# Altium schematic sheet MCU.SchDoc
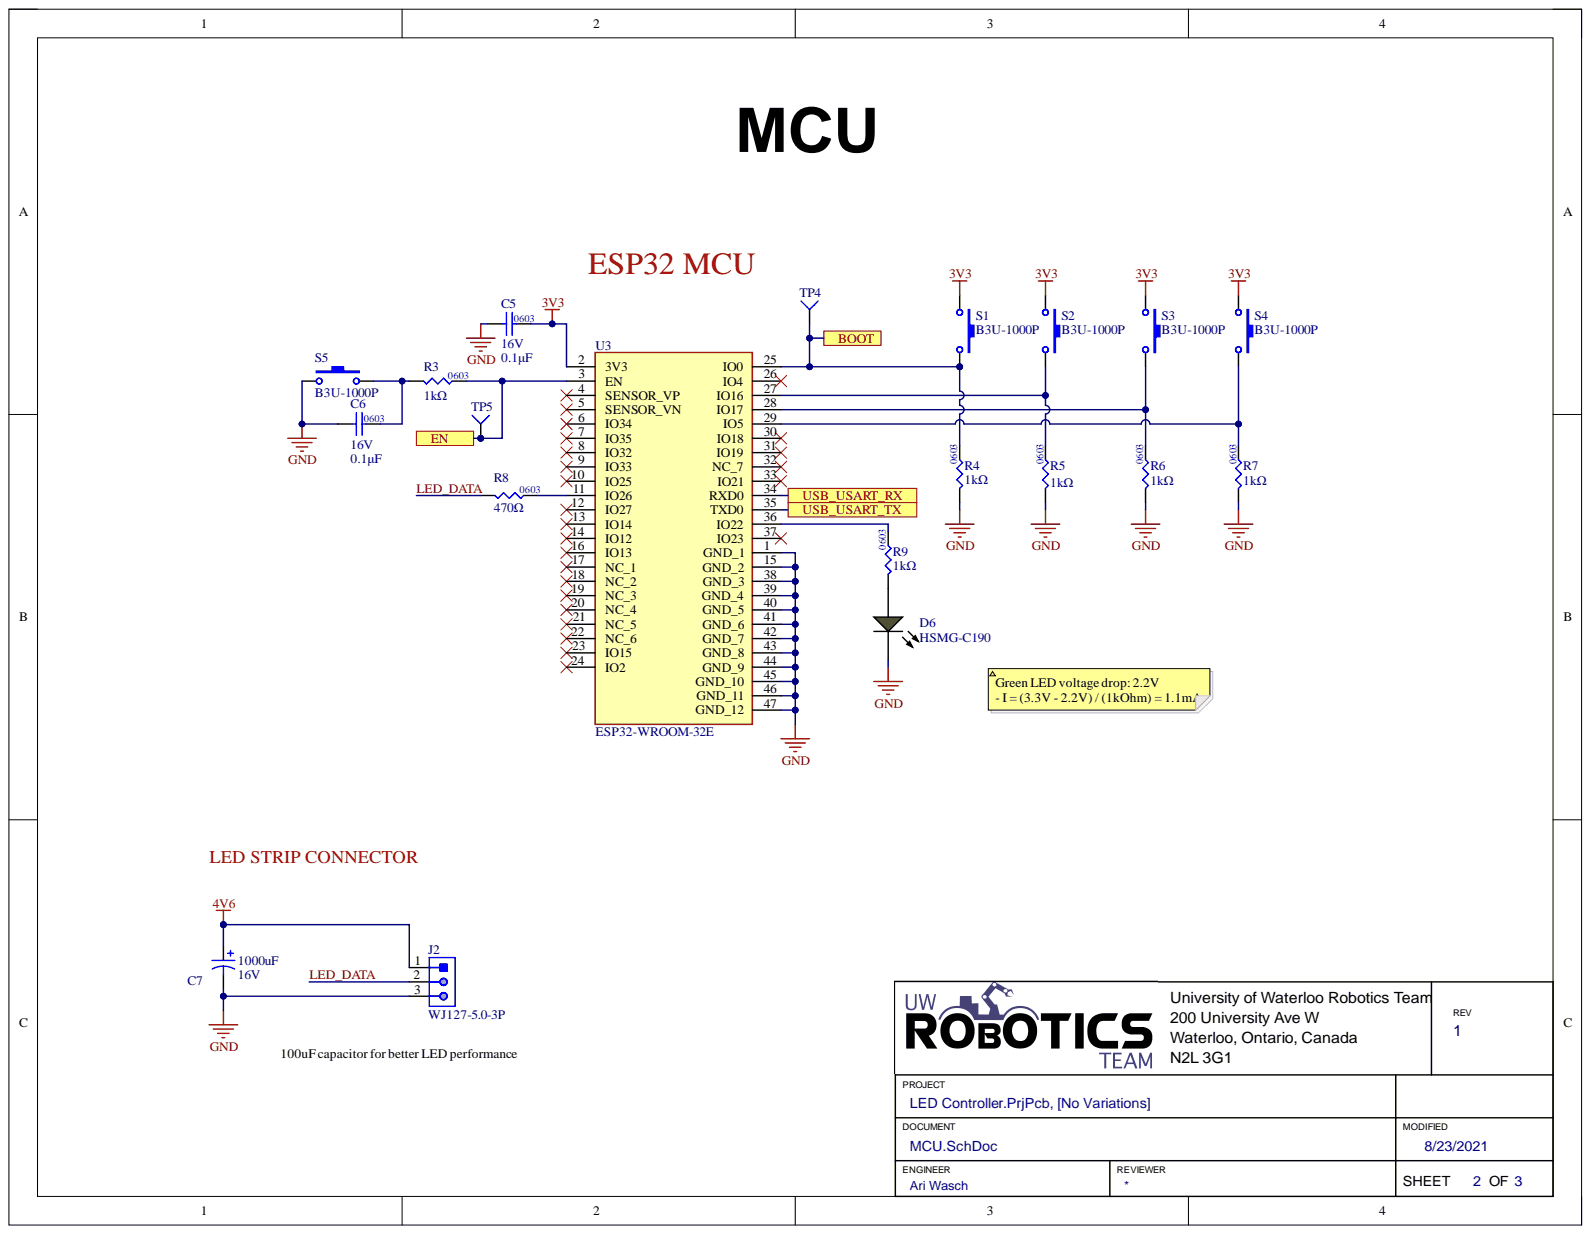
[diagram p0: MCU.SchDoc]

## Parts
C5  value 0.1μF  Package/Footprint: 0603  Voltage Rating: 16V  [CAP0603]  (hidden comment: CAP_0.1uF_16V_0603)
C6  value 0.1μF  Package/Footprint: 0603  Voltage Rating: 16V  [CAP0603]  (hidden comment: CAP_0.1uF_16V_0603)
C7  Capacitance: 1000uF  Voltage: 16V  [CAPAE1030X1050N]  (hidden comment: CAP_1000uF_16V_ALUM)
D6  HSMG-C190  [AVAG-HSMX-C190_V]
J2  WJ127-5.0-3P  [WJ127-5.0-3P]
R3  value 1kΩ  Package/Footprint: 0603  [RES0603]  (hidden comment: RES_1kΩ_0603_5%)
R4  value 1kΩ  Package/Footprint: 0603  [RES0603]  (hidden comment: RES_1kΩ_0603_5%)
R5  value 1kΩ  Package/Footprint: 0603  [RES0603]  (hidden comment: RES_1kΩ_0603_5%)
R6  value 1kΩ  Package/Footprint: 0603  [RES0603]  (hidden comment: RES_1kΩ_0603_5%)
R7  value 1kΩ  Package/Footprint: 0603  [RES0603]  (hidden comment: RES_1kΩ_0603_5%)
R8  value 470Ω  [RESC0603]  (hidden comment: 470R 1%)
R9  value 1kΩ  Package/Footprint: 0603  [RES0603]  (hidden comment: RES_1kΩ_0603_5%)
S1  SW_SPST_NO  [B3U-1000P]  (value not drawn)
S2  SW_SPST_NO  [B3U-1000P]  (value not drawn)
S3  SW_SPST_NO  [B3U-1000P]  (value not drawn)
S4  SW_SPST_NO  [B3U-1000P]  (value not drawn)
S5  SW_SPST_NO  [B3U-1000P]  (value not drawn)
TP4  TESTPOINT_1MM_SQUARE  [TESTPOINT_SQUARE_1MM]  (value not drawn)  (no BOM)
TP5  TESTPOINT_1MM_SQUARE  [TESTPOINT_SQUARE_1MM]  (value not drawn)  (no BOM)
U3  ESP32-WROOM-32E  [ESP32-WROOM-32E]

## Ports
BOOT, EN, USB_USART_RX, USB_USART_TX

## Nets
3V3: C5.2 S1.1 S2.1 S3.1 S4.1 U3.2(3V3)
4V6: C7.1 J2.1
GND: C5.1 C6.1 C7.2 D6.2 J2.3 R4.1 R5.1 R6.1 R7.1 S5.1 U3.1(GND_1) U3.15(GND_2) U3.38(GND_3) U3.39(GND_4) U3.40(GND_5) U3.41(GND_6) U3.42(GND_7) U3.43(GND_8) U3.44(GND_9) U3.45(GND_10) U3.46(GND_11) U3.47(GND_12)
BOOT: R4.2 S1.2 TP4.1 U3.25(IO0) ; port BOOT
EN: R3.2 TP5.1 U3.3(EN) ; port EN
LED_DATA: J2.2 R8.1
USB_USART_RX: U3.34(RXD0) ; port USB_USART_RX
USB_USART_TX: U3.35(TXD0) ; port USB_USART_TX
NetC6_2: C6.2 R3.1 S5.2
NetD6_1: D6.1 R9.1
NetR5_2: R5.2 S2.2 U3.27(IO16)
NetR6_2: R6.2 S3.2 U3.28(IO17)
NetR7_2: R7.2 S4.2 U3.29(IO5)
NetR8_2: R8.2 U3.11(IO26)
NetR9_2: R9.2 U3.36(IO22)

Not connected: U3 4(SENSOR_VP) 5(SENSOR_VN) 6(IO34) 7(IO35) 8(IO32) 9(IO33) 10(IO25) 12(IO27) 13(IO14) 14(IO12) 16(IO13) 17(NC_1) 18(NC_2) 19(NC_3) 20(NC_4) 21(NC_5) 22(NC_6) 23(IO15) 24(IO2) 26(IO4) 30(IO18) 31(IO19) 32(NC_7) 33(IO21) 37(IO23)

## Library data of the parts
C5 C6 = CAP_0.1uF_16V_0603: CAP CER 0.1UF 16V X7R 0603; Manufacturer 1: Yageo; Manufacturer Part Number 1: CC0603KRX7R7BB104; Component Kind: Standard; Dielectric: X7R; LCSC Number: C108079; Supplier 1: Digi-Key; Supplier Part Number 1: 311-1088-1-ND; Library Name: UWRT_Schematic_Library_2020.SchLib
C7 = CAP_1000uF_16V_ALUM: CAP ALUM 1000uF 20% 16V SMD; Manufacturer: Lelon; Manufacturer Part Number 1: VE-102M1CTR-1010; Component Kind: Standard; Datasheet Link: https://datasheet.lcsc.com/szlcsc/1811051743_Lelon-VE-102M1CTR-1010_C249474.pdf; Height: 10.5mm; LCSC Number: C249474; Tolerance: 20%; Library Name: UWRT_Schematic_Library_2020.SchLib
D6 = CMP-1744-00002-1: Chip LED, Green, 2.2 V, -40 to 85 degC, 2-Pin SMD, RoHS, Tape and Reel; Access Time: 3500 ns; Case\Package: SOIC; Clock Frequency: 100 KHz; Density: 32 kb; Frequency: 400 KHz; Interface: Serial; Max Supply Voltage: 5.5 V; Min Supply Voltage: 1.7 V; Nominal Supply Current: 2 mA; Component Kind: Standard; ComponentLink1Description: Manufacturer URL; ComponentLink1URL: http://www.avagotech.com/; ComponentLink2Description: Datasheet; ComponentLink2URL: http://docs.avagotech.com/docs/AV02-0551EN; ComponentLink3Description: Package Specification; ComponentLink3URL: http://www.fairchildsemi.com/dwg/MA/MA03D.pdf; DatasheetVersion: Mar-12; Height: 1.5 mm; LCSC Number: C188729; Length: 5 mm; Library Name: Altium Content Vault; Max Clock Frequency: 100 KHz; Max Operating Temperature: 85 degC; Min Operating Temperature: -40 degC; Mount: Surface Mount; Package Quantity: 3000; PackageDescription: 2-Pin Surface Mount Device, Body 1.6 x 0.8 mm, Height 0.9 mm; PackageReference: HSMX-C190; Packaging: Tape and Reel; Pins: 8; Radiation Hardening: No; REACH SVHC: No SVHC; RoHSCompliant: true; Width: 4 mm
J2 = WJ127-5.0-3P: Manufacturer 1: Ningbo Kangnex; Component Kind: Standard; Datasheet: https://datasheet.lcsc.com/lcsc/2107141401_Ningbo-Kangnex-Elec-WJ127-5-0-3P_C8393.pdf; LCSC Number: C8393; Manufaturer Part Number 1: WJ127-5.0-3P; Supplier 1: LCSC; Library Name: UWRT_Schematic_Library_2020.SchLib
R3-R7 R9 = RES_1kΩ_0603_5%: RES 1K OHM 5% 1/10W 0603; Manufacturer 1: Yageo; Manufacturer Part Number 1: RC0603JR-071KL; Component Kind: Standard; LCSC Number: C14676; Mounting Type: SMD; Supplier 1: Digi-Key; Supplier Part Number 1: 311-1.0KGRCT-ND; Library Name: UWRT_Schematic_Library_2020.SchLib
R8 = RES_470Ω_0603_1%: RES 470 OHM 1% 1/10W 0603; Manufacturer 1: Yageo; Manufacturer Part Number 1: AC0603FR-07470RL; Component Kind: Standard; LCSC Number: C144657; Supplier 1: Digi-Key; Supplier Part Number 1: 311-470LDCT-ND; Library Name: UWRT_Schematic_Library_2020.SchLib
S1-S5 = SW_SPST_NO: SWITCH TACTILE SPST-NO 0.05A 12V; Manufacturer 1: Omron Electronics Inc-EMC Div; Package/Footprint: SMD; Component Kind: Standard; LCSC Number: C231329; Manufacturer Part Number 1: B3U-1000P; Mounting Type: SMD; Supplier 1: Digi-Key; Supplier Part Number 1: SW1020CT-ND; Library Name: UWRT_Schematic_Library_2020.SchLib
TP4 TP5 = TESTPOINT_1MM_SQUARE: TESTPOINT 1MM SQUARE; Library Name: UWRT_Schematic_Library_2020.SchLib
U3 = ESP32-WROOM-32E: Component Kind: Standard; LCSC Number: C529581; Supplier 1: Digi-Key; Supplier Part Number 1: 1965-ESP32-WROOM-32E(16MB)CT-ND; Library Name: UWRT_Schematic_Library_2020.SchLib
